# Altium schematic sheet Main.SchDoc(Main)
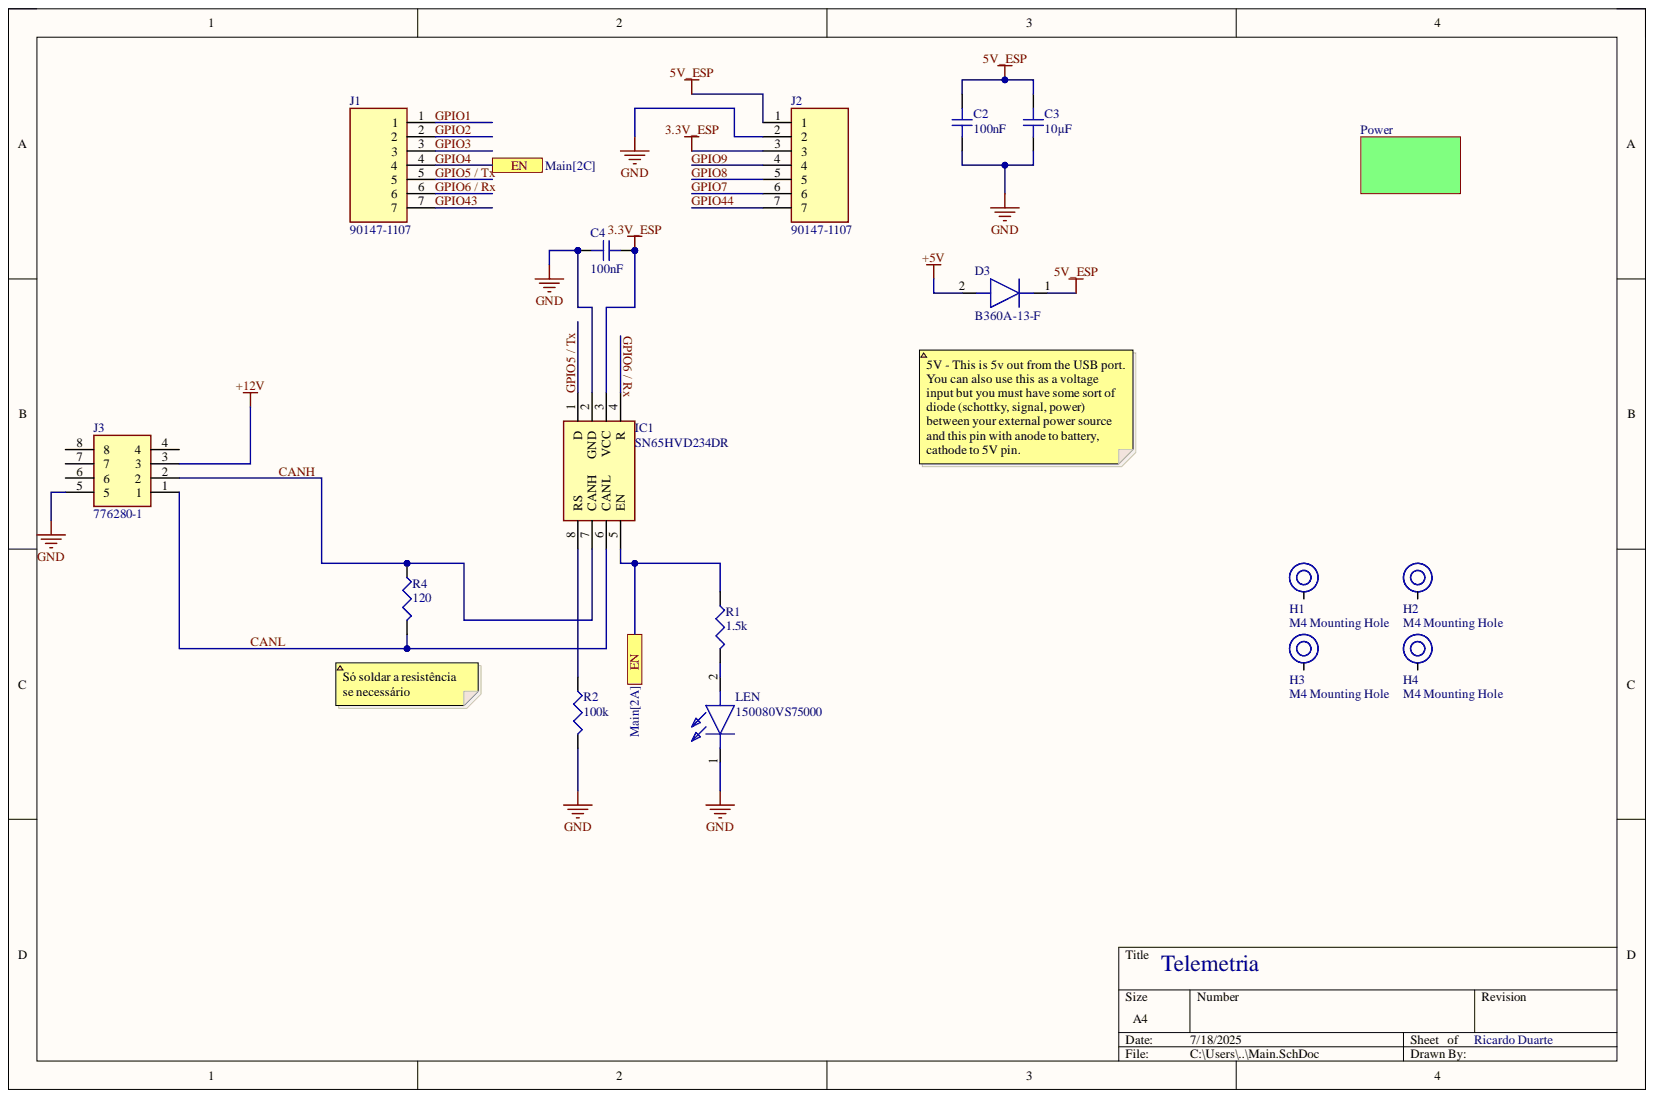
[diagram p0: Main.SchDoc]

## Parts
C2  100nF  [CAP_0805]
C3  value 10µF  [CAP_0805]  (hidden comment: 10uF)
C4  100nF  [CAP_0805]
D3  B360A-13-F  [FP-SMA-MFG]
H1  M4 Mounting Hole  [M4 Screw Hole-Footprint-1]
H2  M4 Mounting Hole  [M4 Screw Hole-Footprint-1]
H3  M4 Mounting Hole  [M4 Screw Hole-Footprint-1]
H4  M4 Mounting Hole  [M4 Screw Hole-Footprint-1]
IC1  SN65HVD234DR  [SOIC127P600X175-8N]
J1  90147-1107  [SHDR7W65P0X254_1X7_2024X510X1180P]
J2  90147-1107  [SHDR7W65P0X254_1X7_2024X510X1180P]
J3  776280-1  [776280-1]
LEN  150080VS75000  [LEDC2012X80N]
R1  value 1.5k  [RES_0805]  (hidden comment: 4K7)
R2  value 100k  [RES_0805]  (hidden comment: 4K7)
R4  value 120  [RES_0805]  (hidden comment: 4K7)

## Ports
EN

## Nets
3.3V_ESP: C4.2 IC1.3(VCC) J2.3
5V_ESP: C2.2 C3.2 D3.1 D3.2 J2.1
+12V: J3.3
GND: C2.1 C3.1 C4.1 IC1.2(GND) J2.2 J3.5 LEN.1 R2.2
CANH: IC1.7(CANH) J3.2 R4.1
CANL: IC1.6(CANL) J3.1 R4.2
GPIO1: J1.1
GPIO2: J1.2
GPIO3: J1.3
GPIO4: IC1.5(EN) J1.4 R1.1 ; port EN
GPIO5 / Tx: IC1.1(D) J1.5
GPIO6 / Rx: IC1.4(R) J1.6
GPIO7: J2.6
GPIO8: J2.5
GPIO9: J2.4
GPIO43: J1.7
GPIO44: J2.7
NetIC1_8: IC1.8(RS) R2.1
NetLEN_2: LEN.2 R1.2

Not connected: H1 1; H2 1; H3 1; H4 1; J3 4 6 7 8

## Library data of the parts
C2 C4 = CMP-001-00010-2: Ceramic Capacitor, Multilayer, Ceramic, 50V, 20% +Tol, 20% -Tol, X7R, 15% TC, 0.1uF, Surface Mount, 0805; Reference Standard: AEC-Q200; Capacitor Type: Ceramic; Case/Package: 0805; Component Kind: Standard; Design Item ID: CAP_0805; Length: 2mm; Max Operating Temperature: 125°C; Min Operating Temperature: -55°C; Mounting Technology: Surface Mount; Rated DC Voltage (URdc): 50V; RoHS Compliant: Yes; Temperature Characteristics Code: X7R; Value: 100nF; Width: 1.25mm; Library Name: EMEA- ISEL Formula Student-Instituto Superior Tecnico - Universidade de Lisboa; Source: Schematic-Lib-PhilsLab.SchLib
C3 = CMP-001-00011-2: Ceramic Capacitor, Multilayer, Ceramic, 25V, 10% +Tol, 10% -Tol, X5R, 15% TC, 10uF, Surface Mount, 0805; Reference Standard: AEC-Q200; Capacitor Type: Ceramic; Case/Package: 0805; Component Kind: Standard; Design Item ID: CAP_0805; Max Operating Temperature: 85°C; Min Operating Temperature: -55°C; Mounting Technology: Surface Mount; Rated DC Voltage (URdc): 25V; RoHS Compliant: Yes; Library Name: EMEA- ISEL Formula Student-Instituto Superior Tecnico - Universidade de Lisboa; Source: Schematic-Lib-PhilsLab.SchLib
D3 = CMP-039-000000-2: Rectifier Diode, Schottky, 1 Phase, 1 Element, 3A, 60V V(RRM), Silicon; Manufacturer_Name: Diodes Inc.; Forward Voltage: 700mV; Arrow Part Number: B360A-13-F; Arrow Price/Stock: https://www.arrow.com/en/products/b360a-13-f/diodes-incorporated?region=asia; Component Kind: Standard; Datasheet Link: https://www.diodes.com/assets/Datasheets/ds30891.pdf; Design Item ID: B360A-13-F; Diode Element Material: SILICON; Diode Type: RECTIFIER; Height: 2.4mm; Manufacturer_Part_Number: B360A-13-F; Max Operating Temperature: 125°C; Max Output current: 3A; Min Operating Temperature: -55°C; Mouser Part Number: 621-B360A-F; Mouser Price/Stock: https://www.mouser.co.uk/ProductDetail/Diodes-Incorporated/B360A-13-F?qs=sVoUGXYOGv3xoCWNHVb4bA%3D%3D; Pins: 2; RoHS Compliant: Yes; Source: SamacSys.SchLib; Technology: SCHOTTKY; Terminal Finish: Tin; Terminal Form: C BEND; Terminal Position: DUAL; Library Name: EMEA- ISEL Formula Student-Instituto Superior Tecnico - Universidade de Lisboa
H1-H4 = CMP-00028-00000-4: IFS Standard M4 hole for mounting PCBs; Component Kind: Standard; Library Name: EMEA- ISEL Formula Student-Instituto Superior Tecnico - Universidade de Lisboa
IC1 = SN65HVD234DR: Integrated Circuit; Manufacturer_Name: Texas Instruments; Arrow Part Number: SN65HVD234DR; Arrow Price/Stock: https://www.arrow.com/en/products/sn65hvd234dr/texas-instruments?region=nac; Component Kind: Standard; Datasheet Link: http://www.ti.com/lit/gpn/sn65hvd234; Height: 1.75mm; Manufacturer_Part_Number: SN65HVD234DR; Mouser Part Number: 595-SN65HVD234DR; Mouser Price/Stock: https://www.mouser.co.uk/ProductDetail/Texas-Instruments/SN65HVD234DR?qs=QViXGNcIEAtzI7GJEWw0wA%3D%3D
J1 J2 = 90147-1107: Connector; Manufacturer_Name: Molex; Component Kind: Standard; Datasheet Link: https://componentsearchengine.com/Datasheets/2/90147-1107.pdf; Height: 11.8mm; Manufacturer_Part_Number: 90147-1107; Mouser Part Number: 538-90147-1107; Mouser Price/Stock: https://www.mouser.co.uk/ProductDetail/Molex/90147-1107?qs=QESXi79X%252BKpbYN2OdNw17A%3D%3D
J3 = CMP-002-00012-1: Connector; Manufacturer_Name: TE Connectivity; Arrow Part Number: 776280-1; Arrow Price/Stock: https://www.arrow.com/en/products/776280-1/te-connectivity; Component Kind: Standard; Datasheet Link: https://www.te.com/commerce/DocumentDelivery/DDEController?Action=srchrtrv&DocNm=1-1773979-2&DocType=Data%20Sheet&DocLang=English&PartCntxt=776280-1&DocFormat=pdf; Design Item ID: 776280-1; Manufacturer_Part_Number: 776280-1; Mouser Part Number: 571-776280-1; Mouser Price/Stock: https://www.mouser.co.uk/ProductDetail/TE-Connectivity/776280-1?qs=tLAa1RwIH6coumJMxuaTDA%3D%3D; Source: SamacSys.SchLib; Library Name: EMEA- ISEL Formula Student-Instituto Superior Tecnico - Universidade de Lisboa
LEN = CMP-018-000003-2: Single Color LED, Bright Green, Water Clear, 1.4mm; Manufacturer_Name: Wurth Elektronik; Forward Current: 30mA; Luminous Intensity-Nom: 40mcd; Viewing Angle: 140°; Color: Green; Component Kind: Standard; Datasheet Link: http://katalog.we-online.com/led/datasheet/150080VS75000.pdf; Design Item ID: 150080VS75000; Height: 0.8mm; Manufacturer_Part_Number: 150080VS75000; Max Operating Temperature: 85°C; Min Operating Temperature: -40°C; Mounting Technology: Surface Mount; Mouser Part Number: 710-150080VS75000; Mouser Price/Stock: https://www.mouser.co.uk/ProductDetail/Wurth-Elektronik/150080VS75000?qs=LlUlMxKIyB0tGHJmO6%252B0ug%3D%3D; Pins: 2; RoHS Compliant: Yes; Size: 1.4mm; Source: SamacSys.SchLib; Library Name: EMEA- ISEL Formula Student-Instituto Superior Tecnico - Universidade de Lisboa
R1 R2 R4 = CMP-009-00012-1: Resistor SMD 0805 4,7K; Component Kind: Standard; Design Item ID: RES_0805; Library Name: EMEA- ISEL Formula Student-Instituto Superior Tecnico - Universidade de Lisboa; Source: Schematic-Lib-PhilsLab.SchLib
